# Altium schematic sheet Schematic 1.SchDoc
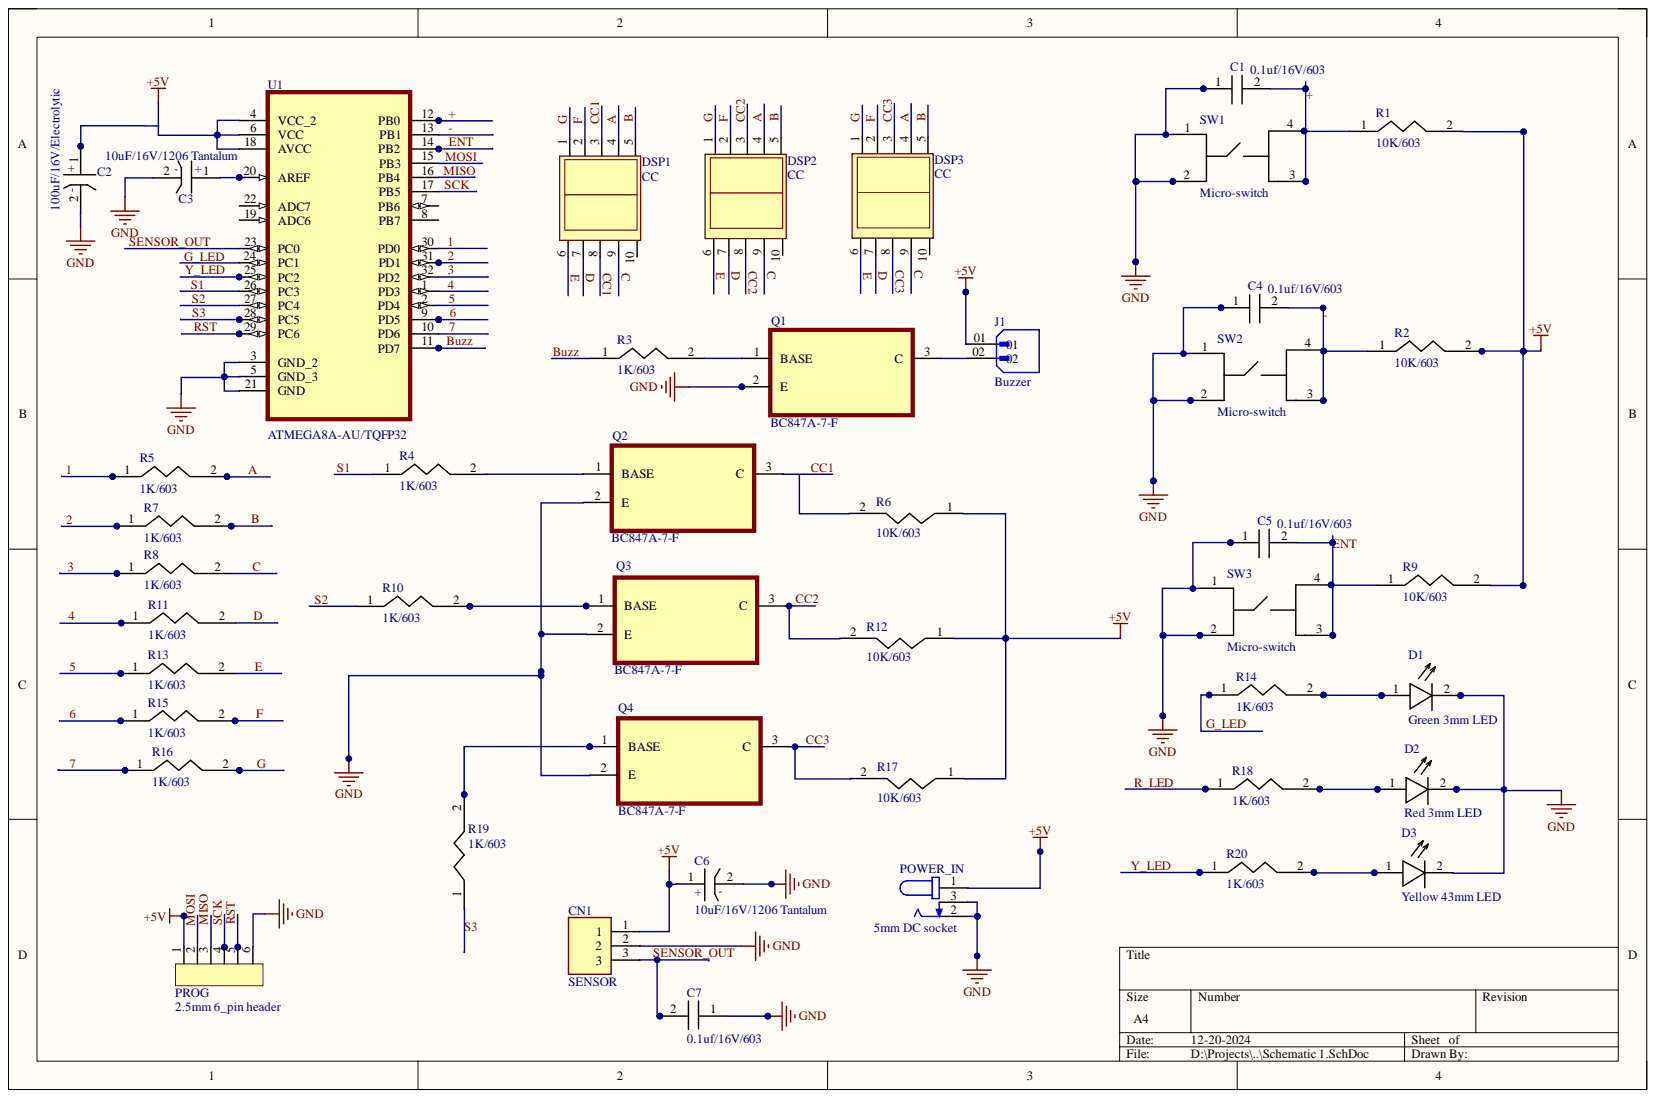
[diagram p0: Schematic 1.SchDoc]

## Parts
C1  0.1uf/16V/603  [603]
C2  100uF/16V/Electrolytic  [8mm Dia Eletrolytic Cap]
C3  10uF/16V/1206 Tantalum  [8mm Dia Eletrolytic Cap]
C4  0.1uf/16V/603  [603]
C5  0.1uf/16V/603  [603]
C6  10uF/16V/1206 Tantalum  [8mm Dia Eletrolytic Cap]
C7  0.1uf/16V/603  [603]
CN1  SENSOR  [TE_282841-3]
D1  Green 3mm LED  [3mm diffused led]
D2  Red 3mm LED  [3mm diffused led]
D3  Yellow 43mm LED  [3mm diffused led]
DSP1  CC  [CC 05 inch 7 segment]
DSP2  CC  [CC 05 inch 7 segment]
DSP3  CC  [CC 05 inch 7 segment]
J1  Buzzer  [WAGO_231-332/001-000]
POWER_IN  5mm DC socket  [CUI_PJ-002A]
PROG  2.5mm 6_pin header  [6_Pin 2.5mm Header]
Q1  BC847A-7-F  [SOT95P240X110-3N]
Q2  BC847A-7-F  [SOT95P240X110-3N]
Q3  BC847A-7-F  [SOT95P240X110-3N]
Q4  BC847A-7-F  [SOT95P240X110-3N]
R1  10K/603  [603]
R2  10K/603  [603]
R3  1K/603  [603]
R4  1K/603  [603]
R5  1K/603  [603]
R6  10K/603  [603]
R7  1K/603  [603]
R8  1K/603  [603]
R9  10K/603  [603]
R10  1K/603  [603]
R11  1K/603  [603]
R12  10K/603  [603]
R13  1K/603  [603]
R14  1K/603  [603]
R15  1K/603  [603]
R16  1K/603  [603]
R17  10K/603  [603]
R18  1K/603  [603]
R19  1K/603  [603]
R20  1K/603  [603]
SW1  Micro-switch  [Tactile switch 4 pin]
SW2  Micro-switch  [Tactile switch 4 pin]
SW3  Micro-switch  [Tactile switch 4 pin]
U1  ATMEGA8A-AU/TQFP32  [QFP80P900X900X120-32N]

## Nets
GND: C1.1 C2.2 C3.2 C4.1 C5.1 C6.2 C7.1 CN1.2 POWER_IN.2 POWER_IN.3 PROG.6 Q1.2 SW1.1 SW1.2 SW2.1 SW2.2 SW3.1 SW3.2
1: R5.1 U1.30(PD0)
2: R7.1 U1.31(PD1)
3: R8.1 U1.32(PD2)
4: R11.1 U1.1(PD3)
5: CN1.1 J1.01 POWER_IN.1 PROG.1 R2.2 R12.1 R13.1 R17.1 U1.2(PD4) U1.4(VCC_2) U1.6(VCC) U1.18(AVCC)
6: R15.1 U1.9(PD5)
7: R16.1 U1.10(PD6)
+: C1.2 U1.12(PB0)
-: C4.2 R2.1 U1.13(PB1)
A: DSP1.4 DSP2.4 DSP3.4 R5.2
B: DSP1.5 DSP2.5 DSP3.5 R7.2
Buzz: R3.1 U1.11(PD7)
C: DSP1.9 DSP2.9 DSP3.9 R8.2
CC1: DSP1.3 DSP1.8 Q2.3
CC2: DSP2.3 DSP2.8 Q3.3
CC3: DSP3.3 DSP3.8 Q4.3
D: DSP1.7 DSP2.7 DSP3.7 R11.2
E: DSP1.6 DSP2.6 DSP3.6 R13.2
ENT: C5.2 U1.14(PB2)
F: DSP1.2 DSP2.2 DSP3.2 R15.2
G: DSP1.1 DSP2.1 DSP3.1 R16.2
G_LED: R14.1 U1.24(PC1)
MISO: PROG.3 U1.16(PB4)
MOSI: PROG.2 U1.15(PB3)
R_LED: R18.1
RST: PROG.5 U1.29(PC6)
S1: R4.1 U1.26(PC3)
S2: R10.1 U1.27(PC4)
S3: R19.1 U1.28(PC5)
SCK: PROG.4 U1.17(PB5)
SENSOR_OUT: C7.2 CN1.3 U1.23(PC0)
Y_LED: R20.1 U1.25(PC2)
NetC3_1: C3.1 U1.20(AREF)
NetD1_1: D1.1 R14.2
NetD1_2: D1.2 D2.2
NetD2_1: D2.1 R18.2
NetD3_1: D3.1 R20.2
NetJ1_02: J1.02 Q1.3
NetQ1_1: Q1.1 R3.2
NetQ2_1: Q2.1 R4.2
NetQ3_1: Q3.1 R10.2
NetQ4_1: Q4.1 R19.2
NetR1_1: R1.1 SW1.4
NetR9_1: R9.1 SW3.4
NetU1_3: U1.3(GND_2) U1.5(GND_3)

Not connected: C2 1; C6 1; D3 2; DSP1 10; DSP2 10; DSP3 10; Q2 2; Q3 2; Q4 2; R1 2; R6 1 2; R9 2; R12 2; R17 2; SW1 3; SW2 3 4; SW3 3; U1 7(PB6) 8(PB7) 19(ADC6) 21(GND) 22(ADC7)

## Library data of the parts
C1 C4 C5 C7 = 603 SMD CAP: Component Kind: Standard; Library Name: Custom1.IntLib
C2 C3 C6 = 8 Dia Cap: Component Kind: Standard; Library Name: Custom1.IntLib
CN1 = 282841-3: Conn Terminal Block 3 POS 5.08mm Solder ST Thru-Hole 17.5A/Contact; MF: TE Connectivity; Availability: In Stock; Check_prices: https://www.snapeda.com/parts/282841-3/TE+Connectivity+AMP+Connectors/view-part/?ref=eda; Component Kind: Standard; EU_RoHS_Compliance: Compliant with Exemptions; Library Name: 282841-3.IntLib; MP: 282841-3; Package: None; Price: None; Purchase-URL: https://pricing.snapeda.com/search?q=282841-3&ref=eda; SnapEDA_Link: https://www.snapeda.com/parts/282841-3/TE+Connectivity+AMP+Connectors/view-part/?ref=snap
D1-D3 = 3mm LED: Component Kind: Standard; Library Name: Custom1.IntLib
DSP1-DSP3 = 7 Segment CC 0.5inch: Component Kind: Standard; Library Name: Custom1.IntLib
J1 = 231-332/001-000: 2 Position Terminal Block Header, Male Pins, Shrouded (4 Side) 0.200 (5.08mm) Vertical Through Hole; MF: WAGO Innovative Connections; Availability: In Stock; Check_prices: https://www.snapeda.com/parts/231-332/001-000/WAGO/view-part/?ref=eda; Component Kind: Standard; Library Name: 231-332_001-000.IntLib; MP: 231-332/001-000; Package: None; Price: None; Purchase-URL: https://pricing.snapeda.com/search/part/231-332/001-000/?ref=eda; SnapEDA_Link: https://www.snapeda.com/parts/231-332/001-000/WAGO/view-part/?ref=snap
POWER_IN = PJ-002A: 2.0 x 6.5 mm, 2.5 A, Horizontal, Through Hole, Dc Power Jack Connector; MF: CUI Devices; Availability: In Stock; Check_prices: https://www.snapeda.com/parts/PJ-002A/CUI+Devices/view-part/?ref=eda; Component Kind: Standard; CUI_purchase_URL: https://www.cuidevices.com/product/interconnect/connectors/dc-power-connectors/jacks/pj-002a?utm_source=snapeda.com&utm_medium=referral&utm_campaign=snapedaBOM; Library Name: PJ-002A.IntLib; MP: PJ-002A; Package: None; Price: None; Purchase-URL: https://pricing.snapeda.com/search/part/PJ-002A/?ref=eda; SnapEDA_Link: https://www.snapeda.com/parts/PJ-002A/CUI+Devices/view-part/?ref=snap
PROG = 6_pin 2.5mm Header: Component Kind: Standard; Library Name: Custom1.IntLib
Q1-Q4 = BC847A-7-F: Bipolar (BJT) Transistor NPN 45V 100mA 300MHz 300mW Surface Mount SOT-23-3; MF: Diodes Inc.; Availability: Good; Check_prices: https://www.snapeda.com/parts/BC847A-7-F/Diodes%20Inc./view-part/484082/?ref=eda; Component Kind: Standard; Library Name: BC847A-7-F.IntLib; MP: BC847A-7-F; Package: SOT-23-3 Diodes Inc.; Price: None; Purchase-URL: https://pricing.snapeda.com/search/part/BC847A-7-F/?ref=eda; SnapEDA_Link: https://www.snapeda.com/parts/BC847A-7-F/Diodes%20Inc./view-part/484082/?ref=snap
R1-R20 = 603 Resistor: Component Kind: Standard; Library Name: Custom1.IntLib
SW1-SW3 = Tactile Switch 4 pin: Component Kind: Standard; Library Name: Custom1.IntLib
U1 = ATMEGA8A-AU: AVR AVRÂ® ATmega Microcontroller IC 8-Bit 16MHz 8KB _4K x 16_ FLASH 32-TQFP _7x7_; MF: Microchip; Availability: Unavailable; Component Kind: Standard; Library Name: ATMEGA8A-AU.IntLib; MP: ATMEGA8A-AU; Package: TQFP-32 Microchip; Price: None; SnapEDA_Link: https://www.snapeda.com/parts/ATMEGA8A-AU/Microchip/view-part/49714/?ref=snap
